# Altium schematic sheet SCH_TEMT6000.SchDoc(SCH_TEMT6000)
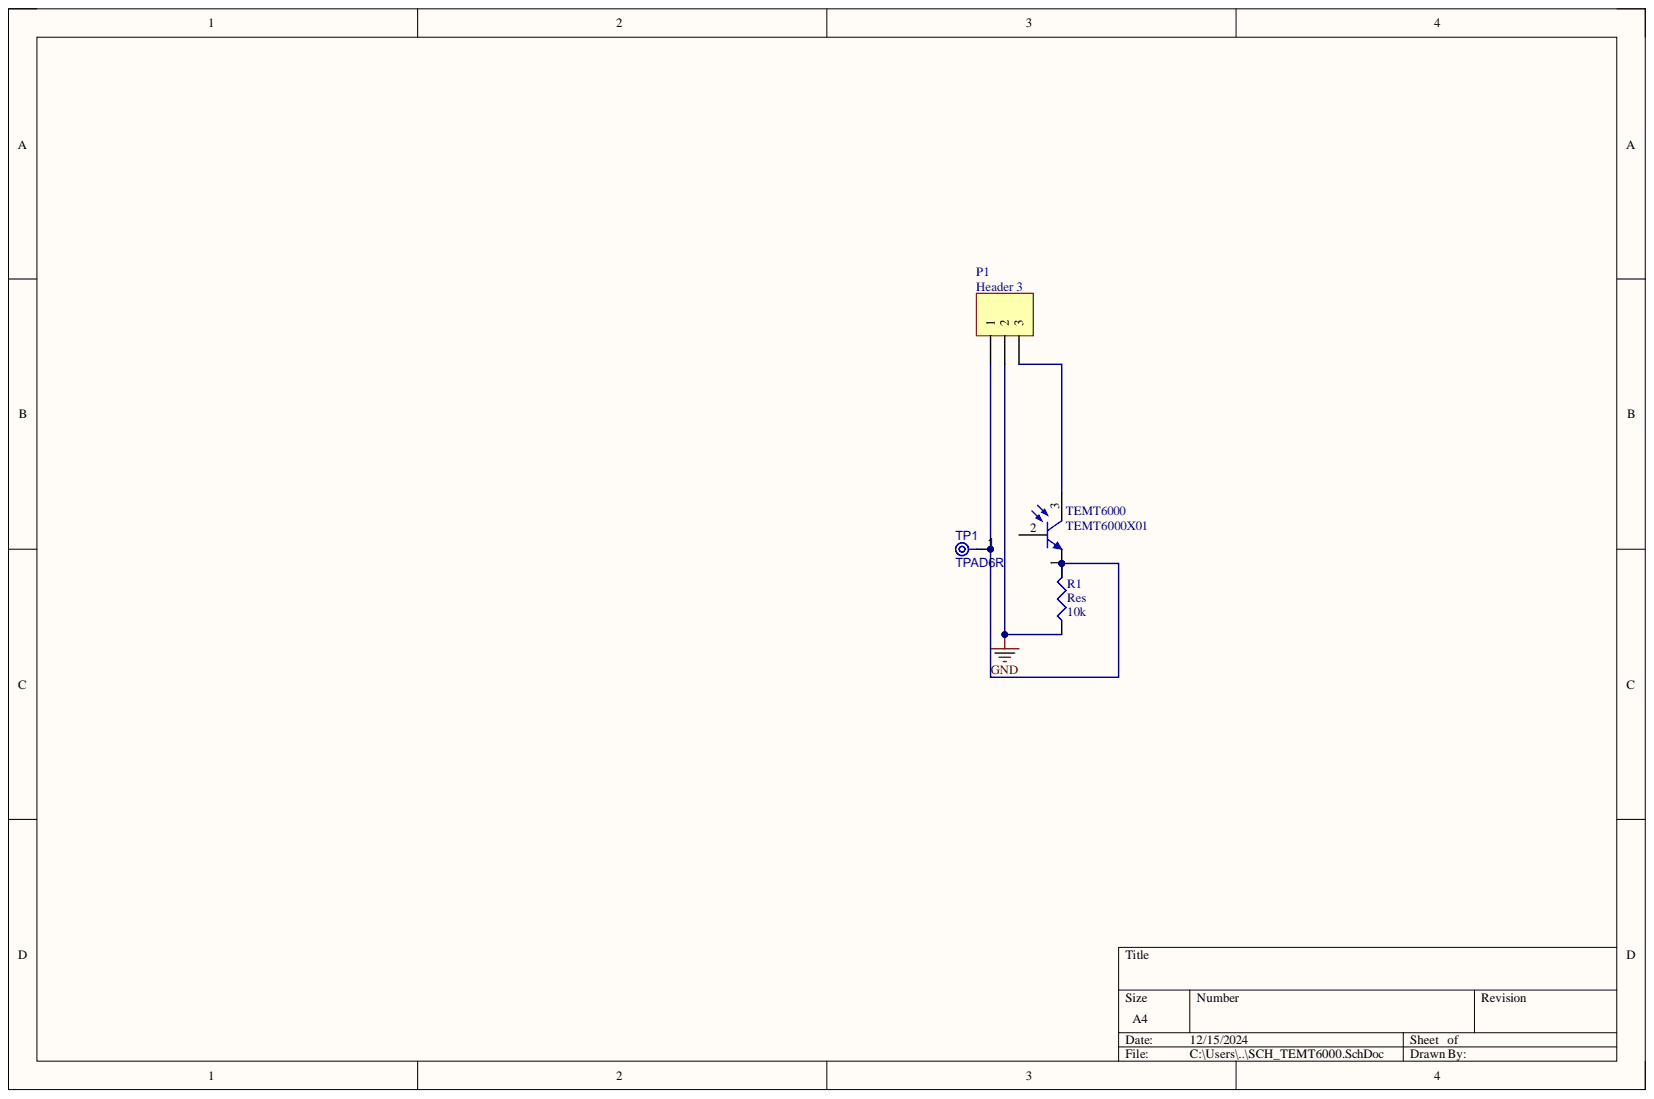
[diagram p0: SCH_TEMT6000.SchDoc]

## Parts
P1  Header 3  [HDR1X3]
R1  Res  value 10k  [J1-0603]
TEMT6000  TEMT6000X01  [VSIL-TEMT6000X01_V]
TP1  TPAD6R  [TP06R]  (no BOM)

## Nets
GND: P1.2 R1.1
NetP1_1: P1.1 R1.2 TEMT6000.1 TP1.1
NetP1_3: P1.3 TEMT6000.3

Not connected: TEMT6000 2

## Library data of the parts
P1 = Header 3: Header, 3-Pin; Component Kind: Standard; LatestRevisionDate: 17-Jul-2002; LatestRevisionNote: Re-released for DXP Platform.; Library Name: Miscellaneous Connectors.IntLib; Signal Integrity: Connector; Publisher: Altium Limited
R1 = Res3: Resistor; Component Kind: Standard; LatestRevisionDate: 29-May-2009; LatestRevisionNote: IPC-7351 Footprint Added.; Library Name: Miscellaneous Devices.IntLib; PackageDescription: Chip Resistor; PackageReference: J1-0603; Published: 8-Jun-2000; Signal Integrity: Res; Simulation: RESISTOR; Publisher: Altium Limited
TEMT6000 = CMP-010-00016-3: Ambient Light Sensor, 100 mW, -40 to 100 degC, 3-Pin SMD, RoHS, Tape and Reel; Manufacturer: Vishay Siliconix; Case/Package: 1206; Component Kind: Standard; Datasheet URL: http://www.vishay.com/docs/81579/temt6000.pdf; Datasheet Version: Rev. 1.9, 08/2011; Mounting Technology: SM; Package Description: 3-Pin Surface Mount Device, Body 4 x 2 mm; Package Reference: 1206; RoHS Compliant: Unknown; Sensor Type: Light; Library Name: Titouan Lasquellec
TP1 = CMP-00028-00002-2: 0.6mm round; Pins: 1; Library Name: Titouan Lasquellec
